# Altium schematic sheet [7] SCS [T 11.9.2 (a)].SchDoc
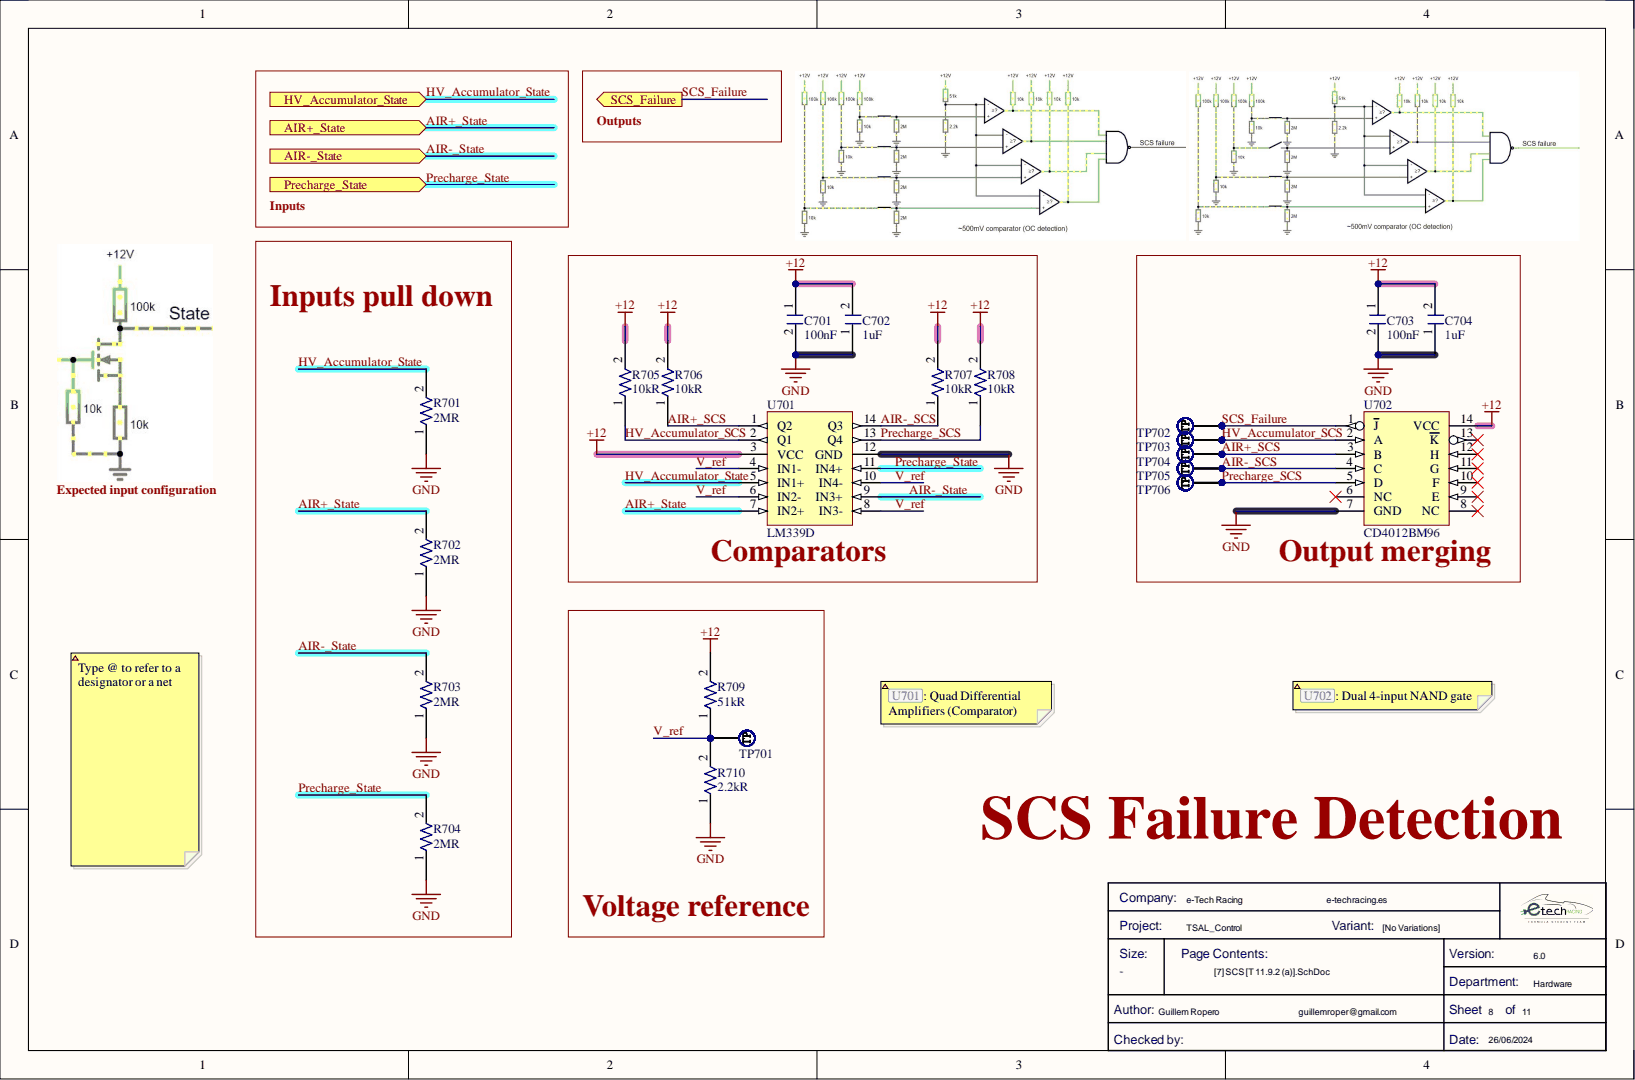
[diagram p0: [7] SCS [T 11.9.2 (a)].SchDoc]

## Parts
C701  Capacitance: 100nF  [C0805FNPC-R]  (hidden comment: 885012207098)
C702  Capacitance: 1uF  [C0805FNPC-R]  (hidden comment: 885012207103)
C703  Capacitance: 100nF  [C0805FNPC-R]  (hidden comment: 885012207098)
C704  Capacitance: 1uF  [C0805FNPC-R]  (hidden comment: 885012207103)
R701  Resistance: 2MR  [R1206FTK-W]  (hidden comment: HVC1206-2M0FT3)
R702  Resistance: 2MR  [R1206FTK-W]  (hidden comment: HVC1206-2M0FT3)
R703  Resistance: 2MR  [R1206FTK-W]  (hidden comment: HVC1206-2M0FT3)
R704  Resistance: 2MR  [R1206FTK-W]  (hidden comment: HVC1206-2M0FT3)
R705  Resistance: 10kR  [R0805FTK]  (hidden comment: CR0805-JW-103ELF)
R706  Resistance: 10kR  [R0805FTK]  (hidden comment: CR0805-JW-103ELF)
R707  Resistance: 10kR  [R0805FTK]  (hidden comment: CR0805-JW-103ELF)
R708  Resistance: 10kR  [R0805FTK]  (hidden comment: CR0805-JW-103ELF)
R709  Resistance: 51kR  [R1206FTK-W]  (hidden comment: CRCW120610K0FKEA)
R710  Resistance: 2.2kR  [R1206FTK-W]  (hidden comment: CR1206-FX-2201ELF)
TP701  TestPoint  [TestPoint]  (value not drawn)  (no BOM)
TP702  TestPoint  [TestPoint]  (value not drawn)  (no BOM)
TP703  TestPoint  [TestPoint]  (value not drawn)  (no BOM)
TP704  TestPoint  [TestPoint]  (value not drawn)  (no BOM)
TP705  TestPoint  [TestPoint]  (value not drawn)  (no BOM)
TP706  TestPoint  [TestPoint]  (value not drawn)  (no BOM)
U701  LM339D  [Array_4_Amp_LM339D]
U702  CD4012BM96  [ARRAY_4_NAND]

## Ports
AIR+_State, AIR-_State, HV_Accumulator_State, Precharge_State, SCS_Failure

## Nets
+12: C701.1 C702.2 C703.1 C704.2 R705.2 R706.2 R707.2 R708.2 R709.2 U701.3(VCC) U702.14(VCC)
GND: C701.2 C702.1 C703.2 C704.1 R701.1 R702.1 R703.1 R704.1 R710.1 U701.12(GND) U702.7(GND)
AIR+_SCS: R706.1 TP704.1 U701.1(Q2) U702.3(B)
AIR+_State: R702.2 U701.7(IN2+) ; port AIR+_State
AIR-_SCS: R707.1 TP705.1 U701.14(Q3) U702.4(C)
AIR-_State: R703.2 U701.9(IN3+) ; port AIR-_State
HV_Accumulator_SCS: R705.1 TP703.1 U701.2(Q1) U702.2(A)
HV_Accumulator_State: R701.2 U701.5(IN1+) ; port HV_Accumulator_State
Precharge_SCS: R708.1 TP706.1 U701.13(Q4) U702.5(D)
Precharge_State: R704.2 U701.11(IN4+) ; port Precharge_State
SCS_Failure: TP702.1 U702.1(J) ; port SCS_Failure
V_ref: R709.1 R710.2 TP701.1 U701.4(IN1-) U701.6(IN2-) U701.8(IN3-) U701.10(IN4-)

Not connected: U702 6(NC) 8(NC) 9(E) 10(F) 11(G) 12(H) 13(K)

## Library data of the parts
C701 C703 = C100n-50V-0805FNPC-X7R: Cap, 0.1ΜF, 50V, 10%, X7R, 0805; Manufacturer 1: Wurth Electronics; .Creator: DR; Case Code (Imperial): 0805; Case Code (Metric): 2012; Case/Package: 0805; Category: Ceramic Capacitors; Component Kind: Standard; ComponentLink1Description: http://www.farnell.com/datasheets/1958498.pdf?src-supplier=Farnell; ComponentLink1URL: http://www.farnell.com/datasheets/1958498.pdf?src-supplier=Farnell; ComponentLink2Description: http://www.farnell.com/datasheets/1958498.pdf?src-supplier=Element14; ComponentLink2URL: http://www.farnell.com/datasheets/1958498.pdf?src-supplier=Element14; ComponentLink3Description: https://katalog.we-online.de/pbs/datasheet/885012207098.pdf?src-supplier=Digi-Key; ComponentLink3URL: https://katalog.we-online.de/pbs/datasheet/885012207098.pdf?src-supplier=Digi-Key; ComponentLink4Description: http://www.farnell.com/datasheets/1958498.pdf?src-supplier=Newark; ComponentLink4URL: http://www.farnell.com/datasheets/1958498.pdf?src-supplier=Newark; Dielectric: X7R; Length: 0.079inch; Library Name: Passives.SchLib; Manufacturer Part Number 1: 885012207098; Max Operating Temperature: 125°C; Min Operating Temperature: -55°C; Mount: Surface Mount; Packaging: Cut Tape; Pricing 1: 100=0,10200, 500=0,07100, 2000=0,06100 (GBP); REACH SVHC: Unknown; RoHS: Yes; RoHS Compliant: Yes; Stock 1: 8000; Supplier 1: RSComponents; Supplier Part Number 1: 1813493; Thickness: 0.035inch; Tolerance: 10%; Voltage Rating: 50V; Voltage Rating (DC): 50V; Width: 0.049inch
C702 C704 = C1u-50V-0805FNPC-X7R: Multilayer Ceramic Capacitor, Wcap-Csgp Series, 1 F, 10%, X7R, 50 V, 0805 [2012 Metric] Rohs Compliant: Yes; Manufacturer 1: Wurth Electronics; .Creator: GP; Voltage Rating: 50V; Case Code (Imperial): 0805; Case Code (Metric): 2012; Case/Package: 0805; Category: Ceramic Capacitors; Component Kind: Standard; ComponentLink1Description: http://www.farnell.com/datasheets/1958501.pdf?src-supplier=Farnell; ComponentLink1URL: http://www.farnell.com/datasheets/1958501.pdf?src-supplier=Farnell; ComponentLink2Description: http://www.farnell.com/datasheets/1958501.pdf?src-supplier=Element14; ComponentLink2URL: http://www.farnell.com/datasheets/1958501.pdf?src-supplier=Element14; ComponentLink3Description: https://katalog.we-online.de/pbs/datasheet/885012107003.pdf?src-supplier=Digi-Key; ComponentLink3URL: https://katalog.we-online.de/pbs/datasheet/885012107003.pdf?src-supplier=Digi-Key; ComponentLink4Description: http://www.farnell.com/datasheets/1958501.pdf?src-supplier=Newark; ComponentLink4URL: http://www.farnell.com/datasheets/1958501.pdf?src-supplier=Newark; Dielectric: X7R; Length: 0.079inch; Library Name: Passives.SchLib; Manufacturer Part Number 1: 885012207103; Max Operating Temperature: 85°C; Min Operating Temperature: -55°C; Mount: Surface Mount; Packaging: Cut Tape; Pricing 1: 50=0,27200, 250=0,25300, 500=0,22800, 1250=0,20100, 2500=0,17100 (GBP); REACH SVHC: Unknown; RoHS: Yes; RoHS Compliant: Yes; Stock 1: 5100; Supplier 1: RSComponents; Supplier Part Number 1: 1813523; Thickness: 0.053inch; Tolerance: 10%; Voltage Rating (DC): 50V; Width: 0.049inch
R701-R704 = R2M-1206FTK: Res Thick Film 1206 2M Ohm 1% 0.3W ±100ppm/C Pad SMD T/R; Manufacturer: TT Welwyn; .Creator: DO; Power Rating: 300mW; Temperature Coefficient: 100ppm/°C; Voltage Rating: 1kV; Case Code (Imperial): 1206; Case Code (Metric): 3216; Category: Uncategorized; Component Kind: Standard; ComponentLink1Description: Datasheet; ComponentLink1URL: https://www.murata.com/en-eu/api/pdfdownloadapi?cate=cgsubHighVoltageResistors&partno=MHR0307SA205G20; ComponentLink2Description: https://datasheet.ciiva.com/28677/1720556-28677405.pdf?src-supplier=Element14; ComponentLink2URL: https://datasheet.ciiva.com/28677/1720556-28677405.pdf?src-supplier=Element14; Library Name: Passives.SchLib; Manufacturer Part Number: HVC1206-2M0FT3; Packaging: Cut Tape; Pricing 1: 3000=1,11000 (USD); REACH SVHC: No SVHC; Resistence: 2MR; Resistor Type: Thick Film; RoHS: Yes; RoHS Compliant: Yes; Supplier 1: Future Electronics; Supplier Part Number 1: 6119491; Tolerance: 1%; Voltage Rating (DC): 1kV
R705-R708 = R10k-0805FTK: BOURNS         CR0805-JW-103ELF            Surface Mount Chip Resistor, Thick Film, CR Series, 10 kohm, 125 mW,  5%, 150 V; .Creator: DR; Max Power Dissipation: 125mW; Temperature Coefficient: 200ppm/°C; Voltage Rating: 150V; Voltage Rating (DC): 100V; Case Code (Imperial): 0805; Case Code (Metric): 2012; Case/Package: 0805; Category: Chip SMD Resistors; Component Kind: Standard; ComponentLink1Description: https://datasheet.ciiva.com/11821/0900766b80fcdb72-11821135.pdf?src-supplier=RSComponents; ComponentLink1URL: https://datasheet.ciiva.com/11821/0900766b80fcdb72-11821135.pdf?src-supplier=RSComponents; ComponentLink2Description: https://datasheet.ciiva.com/9122/chpreztr-9122374.pdf?src-supplier=Avnet; ComponentLink2URL: https://datasheet.ciiva.com/9122/chpreztr-9122374.pdf?src-supplier=Avnet; ComponentLink3Description: https://datasheet.ciiva.com/22693/1902866-22693254.pdf?src-supplier=Element14; ComponentLink3URL: https://datasheet.ciiva.com/22693/1902866-22693254.pdf?src-supplier=Element14; ComponentLink4Description: https://datasheet.ciiva.com/7986/691949-7986187.pdf?src-supplier=Element14; ComponentLink4URL: https://datasheet.ciiva.com/7986/691949-7986187.pdf?src-supplier=Element14; ComponentLink5Description: https://datasheet.ciiva.com/30065/159chpreztr-30065976.pdf?src-supplier=Onlinecomponents.com; ComponentLink5URL: https://datasheet.ciiva.com/30065/159chpreztr-30065976.pdf?src-supplier=Onlinecomponents.com; ComponentLink6Description: https://datasheet.ciiva.com/16783/70154685-16783482.pdf?src-supplier=Allied+Electronics; ComponentLink6URL: https://datasheet.ciiva.com/16783/70154685-16783482.pdf?src-supplier=Allied+Electronics; ComponentLink7Description: https://datasheet.ciiva.com/5548/chpreztr-5548491.pdf?src-supplier=Mouser; ComponentLink7URL: https://datasheet.ciiva.com/5548/chpreztr-5548491.pdf?src-supplier=Mouser; ComponentLink8Description: https://datasheet.ciiva.com/6559/chpreztr-6559900.pdf?src-supplier=Digi-Key; ComponentLink8URL: https://datasheet.ciiva.com/6559/chpreztr-6559900.pdf?src-supplier=Digi-Key; ComponentLink9Description: https://datasheet.ciiva.com/6559/chpreztr-6559901.pdf?src-supplier=Digi-Key; ComponentLink9URL: https://datasheet.ciiva.com/6559/chpreztr-6559901.pdf?src-supplier=Digi-Key; ComponentLink10Description: https://datasheet.ciiva.com/7985/1856384-7985869.pdf?src-supplier=Element14; ComponentLink10URL: https://datasheet.ciiva.com/7985/1856384-7985869.pdf?src-supplier=Element14; ComponentLink11Description: https://datasheet.ciiva.com/48692/001055517-da-01-en-smd-wid-cr0805-jw-103elf-10k-ohm-1-8w-48692118.pdf?src-supplier=Conrad; ComponentLink11URL: https://datasheet.ciiva.com/48692/001055517-da-01-en-smd-wid-cr0805-jw-103elf-10k-ohm-1-8w-48692118.pdf?src-supplier=Conrad; Construction: Rectangular; Contact Plating: Tin; Depth: 1.25mm; Features: Moisture Resistant; Height: 0.5mm; Length: 2mm; Library Name: Passives.SchLib; Manufacturer 1: Bourns; Manufacturer Part Number 1: CR0805-JW-103ELF; Max Operating Temperature: 155°C; Military Standard: Not; Min Operating Temperature: -55°C; Mount: Surface Mount; Number of Pins: 2; Number of Terminations: 2; Package Quantity: 1; Packaging: Tape and Reel; Power Rating: 125mW; Pricing 1: 1=0,05790, 10=0,01540, 25=0,01340, 100=0,00340 (USD); Radiation Hardening: No; REACH SVHC: No SVHC; Resistance Tolerance: 5%; Resistor Type: Thick Film; RoHS: Yes; RoHS Compliant: Yes; Series: CR0805; Stock 1: 57566; Supplier 1: Arrow Electronics; Supplier Part Number 1: CR0805-JW-103ELF; Technology: THICK FILM; Termination: SMD/SMT; Thickness: 508um; Tolerance: 5%; Width: 1.25mm
R709 = R51k-1206FTK: Res Thick Film 1206 51K Ohm 1% 1/4W ±100ppm/°C Molded SMD SMD Punched Carrier T/R; Manufacturer: Panasonic; Manufacturer Part Number: ERJ-S08F5102V; .Creator: DO; Current Rating: 2A; Max Power Dissipation: 250mW; Voltage Rating: 150V; Voltage Rating (DC): 200V; Case Code (Imperial): 1206; Case Code (Metric): 3216; Case/Package: 1206; Category: Resistor; Component Kind: Standard; ComponentLink1Description: Datasheet; ComponentLink1URL: https://docs.rs-online.com/bca8/A700000006404581.pdf; ComponentLink2Description: Where buy it; ComponentLink2URL: https://es.rs-online.com/web/p/resistencias-de-montaje-en-superficie/6791765; ComponentLink3Description: https://datasheet.ciiva.com/24023/aoa0000ce5-24023518.pdf?src-supplier=Mouser; ComponentLink3URL: https://datasheet.ciiva.com/24023/aoa0000ce5-24023518.pdf?src-supplier=Mouser; ComponentLink4Description: https://datasheet.ciiva.com/9189/aoa0000ce5-9189211.pdf?src-supplier=Avnet; ComponentLink4URL: https://datasheet.ciiva.com/9189/aoa0000ce5-9189211.pdf?src-supplier=Avnet; Construction: Rectangular; Contact Plating: Tin; Depth: 1.6mm; Features: Anti-Sulfur; Height: 0.028inch; Lead Free: Lead Free; Length: 3.2mm; Library Name: Passives.SchLib; Max Operating Temperature: 155°C; Military Standard: Not; Min Operating Temperature: -55°C; Mount: Surface Mount; Number of Terminations: 2; Package Quantity: 5000; Packaging: Tape and Reel; Power Rating: 250mW; Pricing 1: 1=0,31000, 10=0,26700, 50=0,26700, 100=0,10700, 1000=0,05100, 10000=0,03400 (USD); Resistor Type: Thick Film; RoHS: Yes; RoHS Compliant: Yes; Series: ERJ; Stock 1: 4091; Supplier 1: Mouser; Supplier Part Number 1: 667-ERJ-S08F5102V; Temperature Coefficient: 100ppm/°C; Tolerance: 1%; Width: 0.063inch
R710 = R2.2K-1206FTK: Res Thick Film 1206 2.2K Ohm 1% 1/4W ±100ppm/°C Molded SMD SMD Paper Tape on Plastic Reel; Manufacturer 1: Bourns; .Creator: AC; Max Power Dissipation: 250mW; Voltage Rating: 200V; Case Code (Imperial): 1206; Case Code (Metric): 3216; Case/Package: 1206; Category: Chip SMD Resistors; Component Kind: Standard; ComponentLink1Description: https://datasheet.ciiva.com/11809/0900766b80fcdb72-11809898.pdf?src-supplier=RSComponents; ComponentLink1URL: https://datasheet.ciiva.com/11809/0900766b80fcdb72-11809898.pdf?src-supplier=RSComponents; ComponentLink2Description: https://datasheet.ciiva.com/9123/chpreztr-9123227.pdf?src-supplier=Avnet; ComponentLink2URL: https://datasheet.ciiva.com/9123/chpreztr-9123227.pdf?src-supplier=Avnet; ComponentLink3Description: https://datasheet.ciiva.com/16770/70154685-16770447.pdf?src-supplier=Allied+Electronics; ComponentLink3URL: https://datasheet.ciiva.com/16770/70154685-16770447.pdf?src-supplier=Allied+Electronics; ComponentLink4Description: https://datasheet.ciiva.com/7985/1856384-7985879.pdf?src-supplier=Element14; ComponentLink4URL: https://datasheet.ciiva.com/7985/1856384-7985879.pdf?src-supplier=Element14; ComponentLink5Description: https://datasheet.ciiva.com/22693/1902866-22693258.pdf?src-supplier=Element14; ComponentLink5URL: https://datasheet.ciiva.com/22693/1902866-22693258.pdf?src-supplier=Element14; ComponentLink6Description: RS; ComponentLink6URL: https://es.rs-online.com/web/p/resistencias-fijas-para-montaje-en-superficie/7409097/; Construction: Rectangular; Contact Plating: Tin; Depth: 1.6mm; Features: Moisture Resistant; Height: 0.6mm; Length: 3.2mm; Library Name: Passives.SchLib; Manufacturer Part Number 1: CR1206-FX-2201ELF; Max Operating Temperature: 155°C; Military Standard: Not; Min Operating Temperature: -55°C; Mount: Surface Mount; Number of Pins: 2; Number of Terminations: 2; Package Quantity: 5000; Packaging: Tape and Reel; Power Rating: 250mW; Pricing 1: 1=0,10000, 10=0,04200, 100=0,01700, 1000=0,00762, 2500=0,00661 (USD); Radiation Hardening: No; REACH SVHC: No SVHC; Resistance Tolerance: 1%; Resistor Type: Thick Film; RoHS: Yes; RoHS Compliant: Yes; Series: CR1206; Stock 1: 150; Supplier 1: Digi-Key; Supplier Part Number 1: CR1206-FX-2201ELFCT-ND; Technology: THICK FILM; Temperature Coefficient: 100ppm/°C; Termination: SMD/SMT; Thickness: 610um; Tolerance: 1%; Voltage Rating (DC): 200V; Width: 1.6mm
TP701-TP706 = TestPoint: Test Point 1x1 mm; .Creator: GP; Category: TestPoint; Library Name: e-Tech_Racing.SchLib; .PCBcheck: GP; .SCHcheck: GP
U701 = Array_4_Comp_LM339D: Comparator Quad ±18V/36V 14-Pin SOIC Tube; Manufacturer: Texas Instruments; .Creator: DO; Dual Supply Voltage: 9V; Input Bias Current: 250nA; Input Offset Voltage (Vos): 5mV; Max Dual Supply Voltage: 15V; Max Supply Current: 500uA; Max Supply Voltage: 30V; Min Dual Supply Voltage: 1V; Min Supply Voltage: 2V; Nominal Supply Current: 2mA; Number of Channels: 4; Output Current: 20mA; Output Current per Channel: 6mA; Output Type: TTL; Quiescent Current: 2.5mA; Response Time: 0.3us; Voltage Gain: 106.02dB; Case/Package: SOIC; Category: Amplifiers - Op Amps, Buffer, Instrumentation; Component Kind: Standard; ComponentLink1Description: https://datasheet.ciiva.com/15131/getdatasheetpartid-248452-15131099.pdf?src-supplier=Verical; ComponentLink1URL: https://datasheet.ciiva.com/15131/getdatasheetpartid-248452-15131099.pdf?src-supplier=Verical; ComponentLink2Description: https://datasheet.ciiva.com/1483/1831045-1483000.pdf?src-supplier=Element14; ComponentLink2URL: https://datasheet.ciiva.com/1483/1831045-1483000.pdf?src-supplier=Element14; ComponentLink3Description: https://datasheet.ciiva.com/1827/lm139a-1827534.pdf?src-supplier=Digi-Key; ComponentLink3URL: https://datasheet.ciiva.com/1827/lm139a-1827534.pdf?src-supplier=Digi-Key; ComponentLink4Description: https://datasheet.ciiva.com/2970/lm2901-2970484.pdf?src-supplier=Avnet; ComponentLink4URL: https://datasheet.ciiva.com/2970/lm2901-2970484.pdf?src-supplier=Avnet; ComponentLink5Description: https://datasheet.ciiva.com/48712/001010753-da-01-en-ic-quad-diff-comp-lm339d-soic-14-tid-48712470.pdf?src-supplier=Conrad; ComponentLink5URL: https://datasheet.ciiva.com/48712/001010753-da-01-en-ic-quad-diff-comp-lm339d-soic-14-tid-48712470.pdf?src-supplier=Conrad; ComponentLink6Description: Where buy it; ComponentLink6URL: https://es.rs-online.com/web/p/comparadores/0858411; Contact Plating: Gold; Height: 1.58mm; Lead Free: Lead Free; Length: 8.65mm; Library Name: Integrated circuits.SchLib; Manufacturer Part Number: LM339D; Max Input Current: 250nA; Max Operating Temperature: 70°C; Min Operating Temperature: 0°C; Mount: Surface Mount; Number of Elements: 4; Number of Pins: 14; Package Quantity: 50; Packaging: Rail/Tube; Pricing 1: 1=0,93000, 10=0,83500, 25=0,79240, 100=0,65090, 250=0,60848, 500=0,53774, 1000=0,46725 (USD); Radiation Hardening: No; REACH SVHC: No SVHC; RoHS: Yes; RoHS Compliant: Yes; Series: LM339; Stock 1: 10834; Supplier 1: Digi-Key; Supplier Part Number 1: 296-1013-5-ND; Supply Current: 2mA; Technology: BIPOLAR; Weight: 0.004318oz; Width: 3.91mm
U702 = Array_2x4_NAND: 2-ch, 4-input, 3-V to 18-V NAND gates 14-SOIC -55 to 125; Manufacturer 1: Texas Instruments; High Level Output Current: 3.4mA; Max Supply Voltage: 18V; Min Supply Voltage: 3V; Number of Bits: 2; Number of Input Lines: 4; Number of Output Lines: 1; Output Current: 6.8mA; Propagation Delay: 90ns; Quiescent Current: 5uA; Schmitt Trigger Input: No; Turn-On Delay Time: 250ns; Case/Package: SOIC; Category: Logic Gates; Component Kind: Standard; ComponentLink1Description: https://datasheet.ciiva.com/1865/cd4011b-1865256.pdf?src-supplier=Digi-Key; ComponentLink1URL: https://datasheet.ciiva.com/1865/cd4011b-1865256.pdf?src-supplier=Digi-Key; ComponentLink2Description: https://datasheet.ciiva.com/1865/cd4011b-1865255.pdf?src-supplier=Digi-Key; ComponentLink2URL: https://datasheet.ciiva.com/1865/cd4011b-1865255.pdf?src-supplier=Digi-Key; ComponentLink3Description: https://datasheet.ciiva.com/1865/cd4011b-1865257.pdf?src-supplier=Digi-Key; ComponentLink3URL: https://datasheet.ciiva.com/1865/cd4011b-1865257.pdf?src-supplier=Digi-Key; ComponentLink4Description: https://datasheet.ciiva.com/14307/cd4023b-14307967.pdf?src-supplier=Avnet; ComponentLink4URL: https://datasheet.ciiva.com/14307/cd4023b-14307967.pdf?src-supplier=Avnet; Contact Plating: Gold; Height: 1.58mm; Lead Free: Lead Free; Length: 8.65mm; Library Name: Integrated circuits.SchLib; Logic Function: NAND GATE; Low Level Output Current: 3.4mA; Manufacturer Part Number 1: CD4012BM96; Max Operating Temperature: 125°C; Min Operating Temperature: -55°C; Mount: Surface Mount; Number of Circuits: 2; Number of Elements: 2; Number of Gates: 2; Number of Inputs: 4; Number of Outputs: 1; Number of Pins: 14; Package Quantity: 1; Packaging: Tape and Reel; Pricing 1: 1=0,66000, 10=0,56800, 25=0,53040, 100=0,42440, 250=0,39412, 500=0,33348, 1000=0,25769 (USD); Radiation Hardening: No; Reach SVHC Compliant: Yes; RoHS: Yes; RoHS Compliant: Yes; Series: 4000B; Stock 1: 5313; Supplier 1: Digi-Key; Supplier Part Number 1: 296-14246-1-ND; Technology: CMOS; Weight: 0.004564oz; Width: 3.91mm
